# Altium schematic sheet lather_front_panel.SchDoc(lather_front_panel)
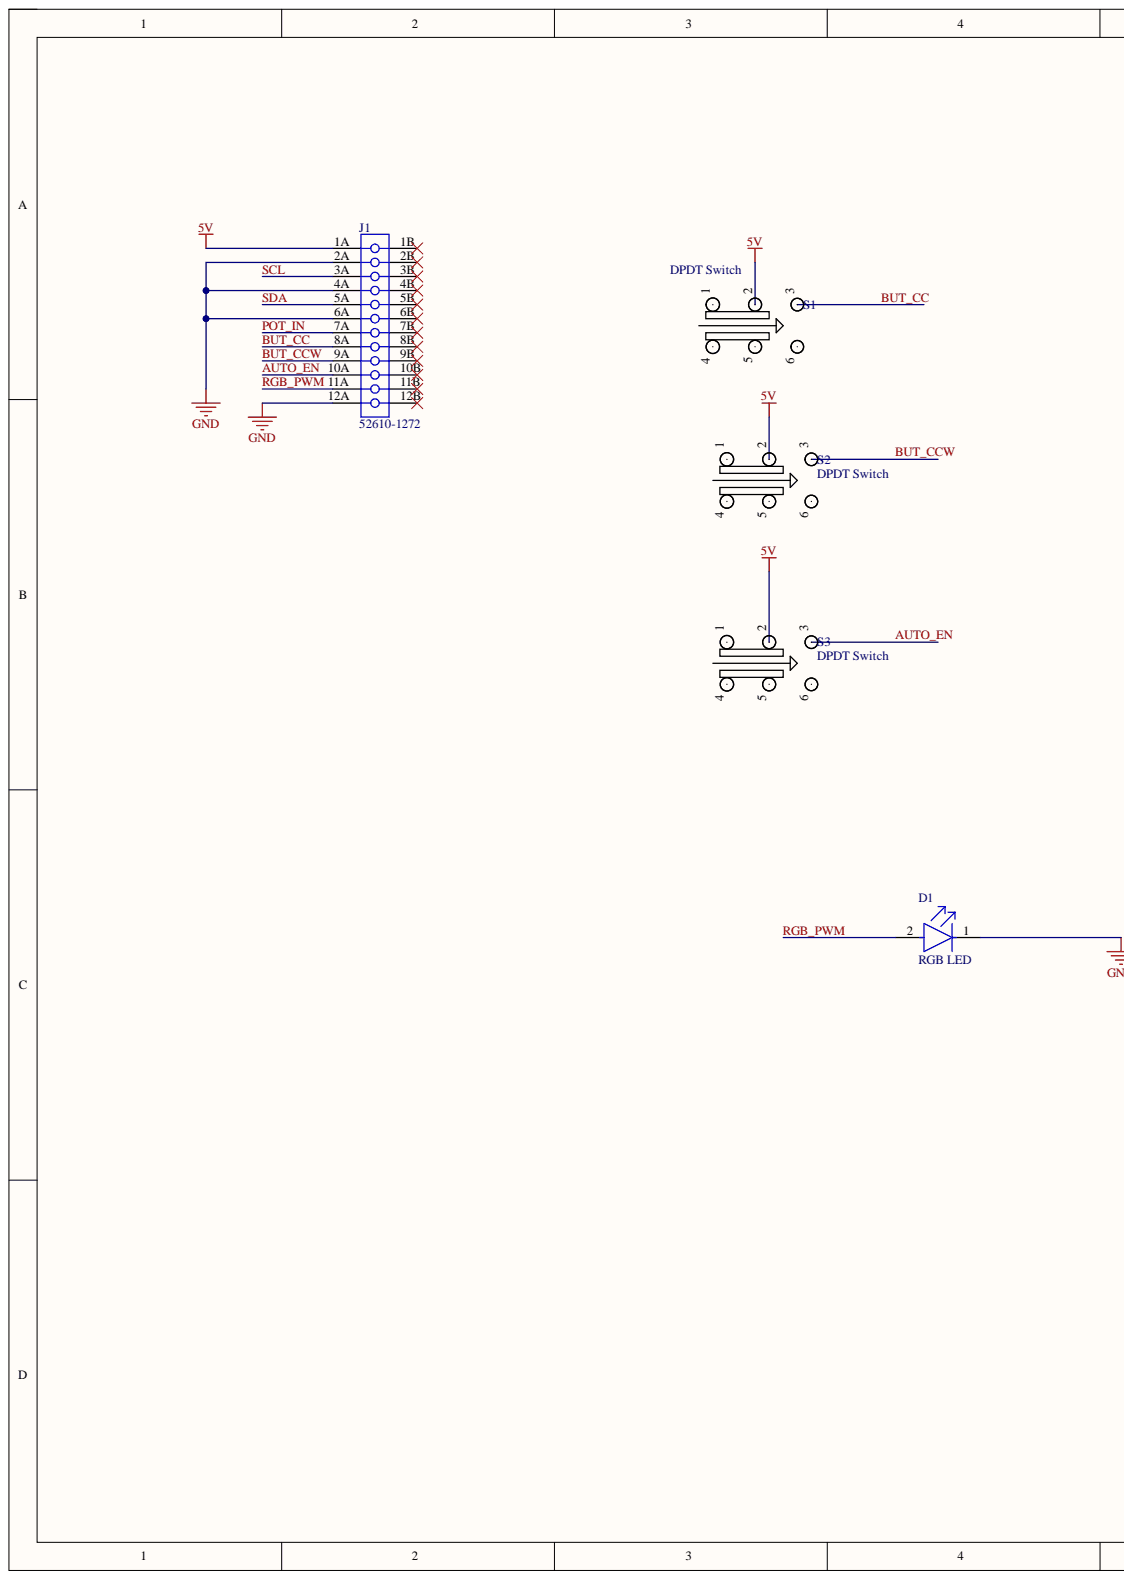
[diagram p0: lather_front_panel.SchDoc, left]
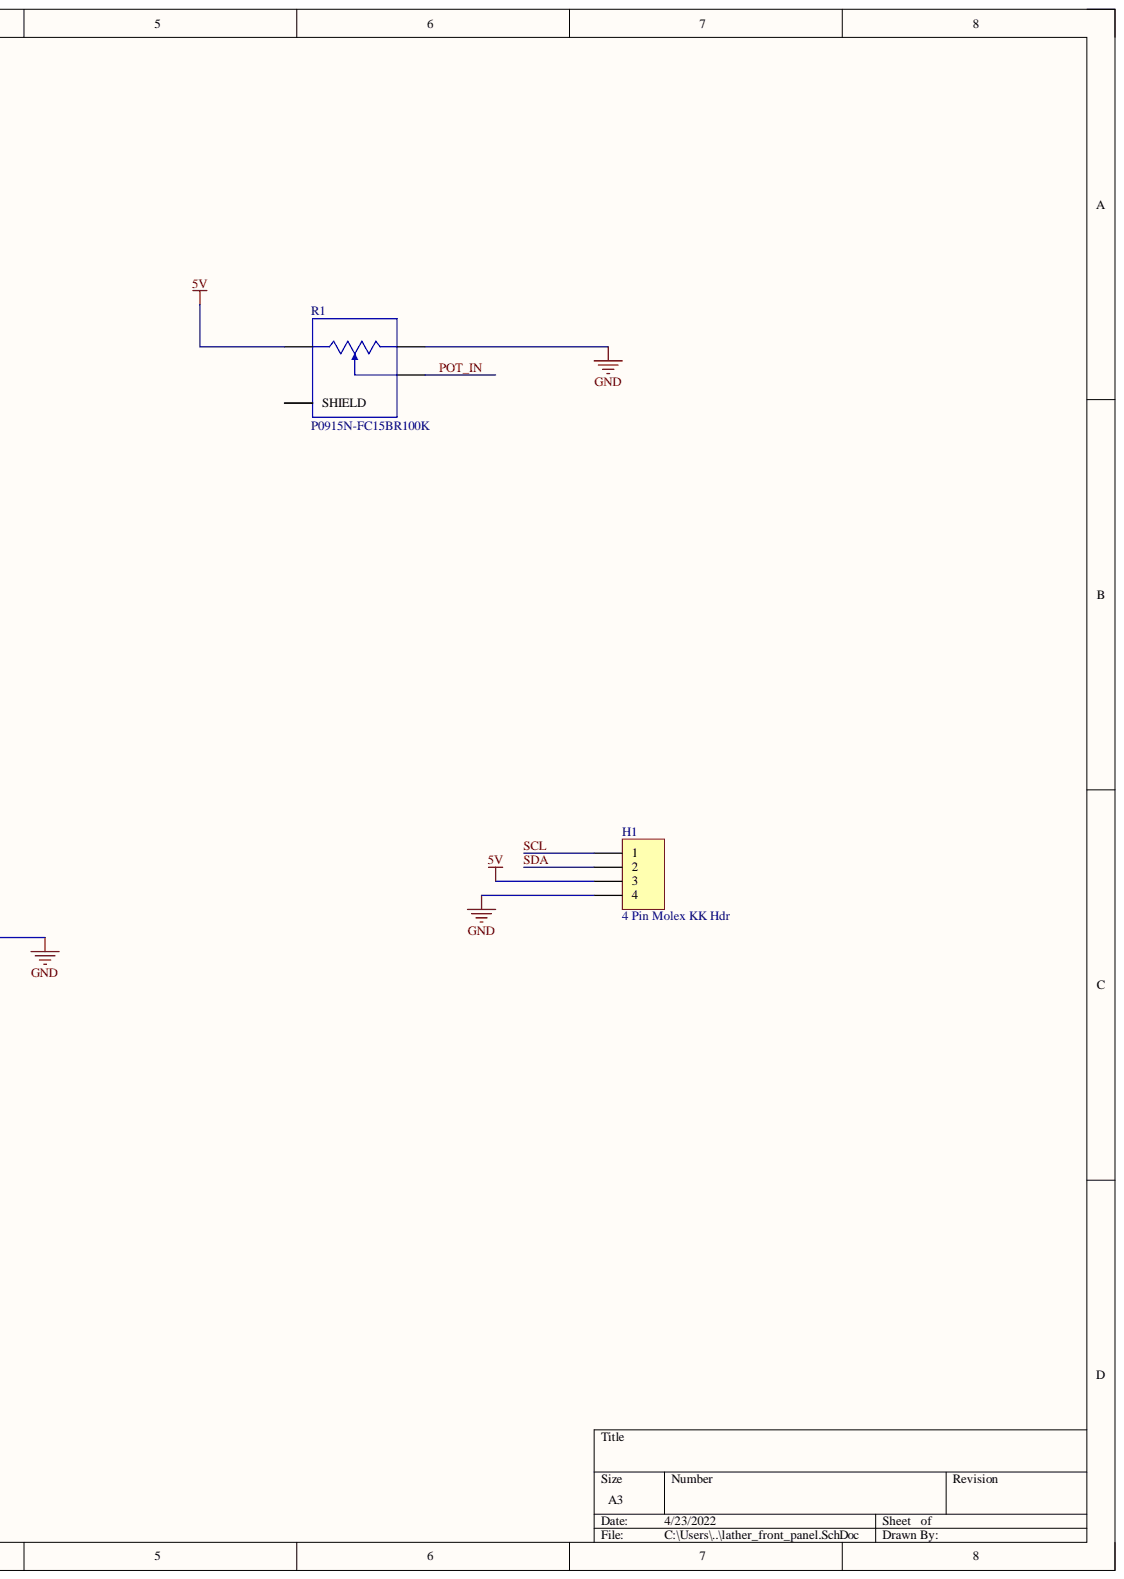
[diagram p1: lather_front_panel.SchDoc, right]

## Parts
D1  RGB LED  [INDRD500W70D900H1220]
H1  4 Pin Molex KK Hdr  Manufacturer: Molex  [MOLEX_KK_4_HDR]
J1  52610-1272  Current (Max per Contact): 1A  [MOLX-52610-1272]
R1  P0915N-FC15BR100K  [TRIM_P0915N-FC15BR100K]
S1  DPDT Switch  [TL2230EEF100]
S2  DPDT Switch  [TL2230EEF100]
S3  DPDT Switch  [TL2230EEF100]

## Nets
5V: H1.3 J1.1A R1.1 S1.2 S2.2 S3.2
GND: D1.1 H1.4 J1.2A J1.4A J1.6A J1.12A R1.3
AUTO_EN: J1.10A S3.3
BUT_CC: J1.8A S1.3
BUT_CCW: J1.9A S2.3
POT_IN: J1.7A R1.2
RGB_PWM: D1.2 J1.11A
SCL: H1.1 J1.3A
SDA: H1.2 J1.5A
NetR1_S1: R1.S1(SHIELD) R1.S2

Not connected: J1 1B 2B 3B 4B 5B 6B 7B 8B 9B 10B 11B 12B; S1 1 4 5 6; S2 1 4 5 6; S3 1 4 5 6

## Library data of the parts
H1 = JA_MOLEX_KK_4POS: CONN HEADER 4POS 2.54mm VERT_Thru Hole; Component Kind: Standard; Library Name: ECE AD19Components_22V1.IntLib; Manufacturer PN: 22-23-2041; Signal Integrity: Connector; Storage Location: ECE Dept Store; Supplier: ECE Dept; Supplier 1: Farnell; Supplier Part Number 1: 9731164; Value: 4 Pin Molex KK Hdr
J1 = CMP-1241-00323-1: 1.0 mm Pitch Easy-On(TM) Type FPC Connector, 5.75 mm Mated Height, Vertical, ZIF, SMT, 12 Circuits, -40 to 85 degC, ELV and RoHS Compliant, Tape and Reel; Manufacturer: Molex; Circuits (Loaded): 12; Durability (mating cycles max): 30; Mated Height: 5.75mm; Material - Metal: Phosphor Bronze; Material - Plating Mating: Gold; Material - Plating Termination: Tin; PCB Locator: No; PCB Retention: None; Pitch - Mating Interface: 1.00mm; Voltage (Max): 125V AC (RMS)/DC; Component Kind: Standard; ComponentLink1Description: Manufacturer URL; ComponentLink1URL: http://www.molex.com/molex/index.jsp; ComponentLink2Description: Datasheet; ComponentLink2URL: http://www.molex.com/webdocs/datasheets/pdf/en-us/0526101272_FFC_FPC_CONNECTORS.pdf; ComponentLink3Description: Package Specification; ComponentLink3URL: http://www.molex.com/pdm_docs/sd/526101272_sd.pdf; Contact Position: N/A; Entry Angle: Vertical; Library Name: Altium Content Vault; Mounting Technology: Surface Mount; Number of Rows: 1; Orientation: Vertical; PackageDescription: 12-Lead FPC Connector, Pitch 1.0 mm; PackageReference: 52610-1272; PackageVersion: Rev. G, 02/2012; Packing: Tape and Reel; PartNumber: 52610-1272; Polarized to PCB: No; RoHS: TRUE; Stackable: No
R1 = P0915N-FC15BR100K: 100k Ohm 1 Gang Linear Panel Mount Potentiometer None 1 Kierros Conductive Plastic 0.05W, 1/20W PC Pins, Board Locks; MF: TT Electronics/BI; Availability: Warning; Check_prices: https://www.snapeda.com/parts/P0915N-FC15BR100K/TT%20Electronics/BI/view-part/1438723/?ref=eda; Component Kind: Standard; MP: P0915N-FC15BR100K; Package: None; Price: None; Purchase-URL: https://pricing.snapeda.com/search/part/P0915N-FC15BR100K/?ref=eda; SnapEDA_Link: https://www.snapeda.com/parts/P0915N-FC15BR100K/TT%20Electronics/BI/view-part/1438723/?ref=snap; Library Name: lathe_library.IntLib
S1-S3 = TL2230EEF100: Component Kind: Standard; Library Name: lathe_library.IntLib
D1 = RGB LED: Component Kind: Standard; Description: RGB LED; Library Name: lathe_library.IntLib
